# Altium schematic sheet STM32F407ZET6.SchDoc(STM32F407ZET6)
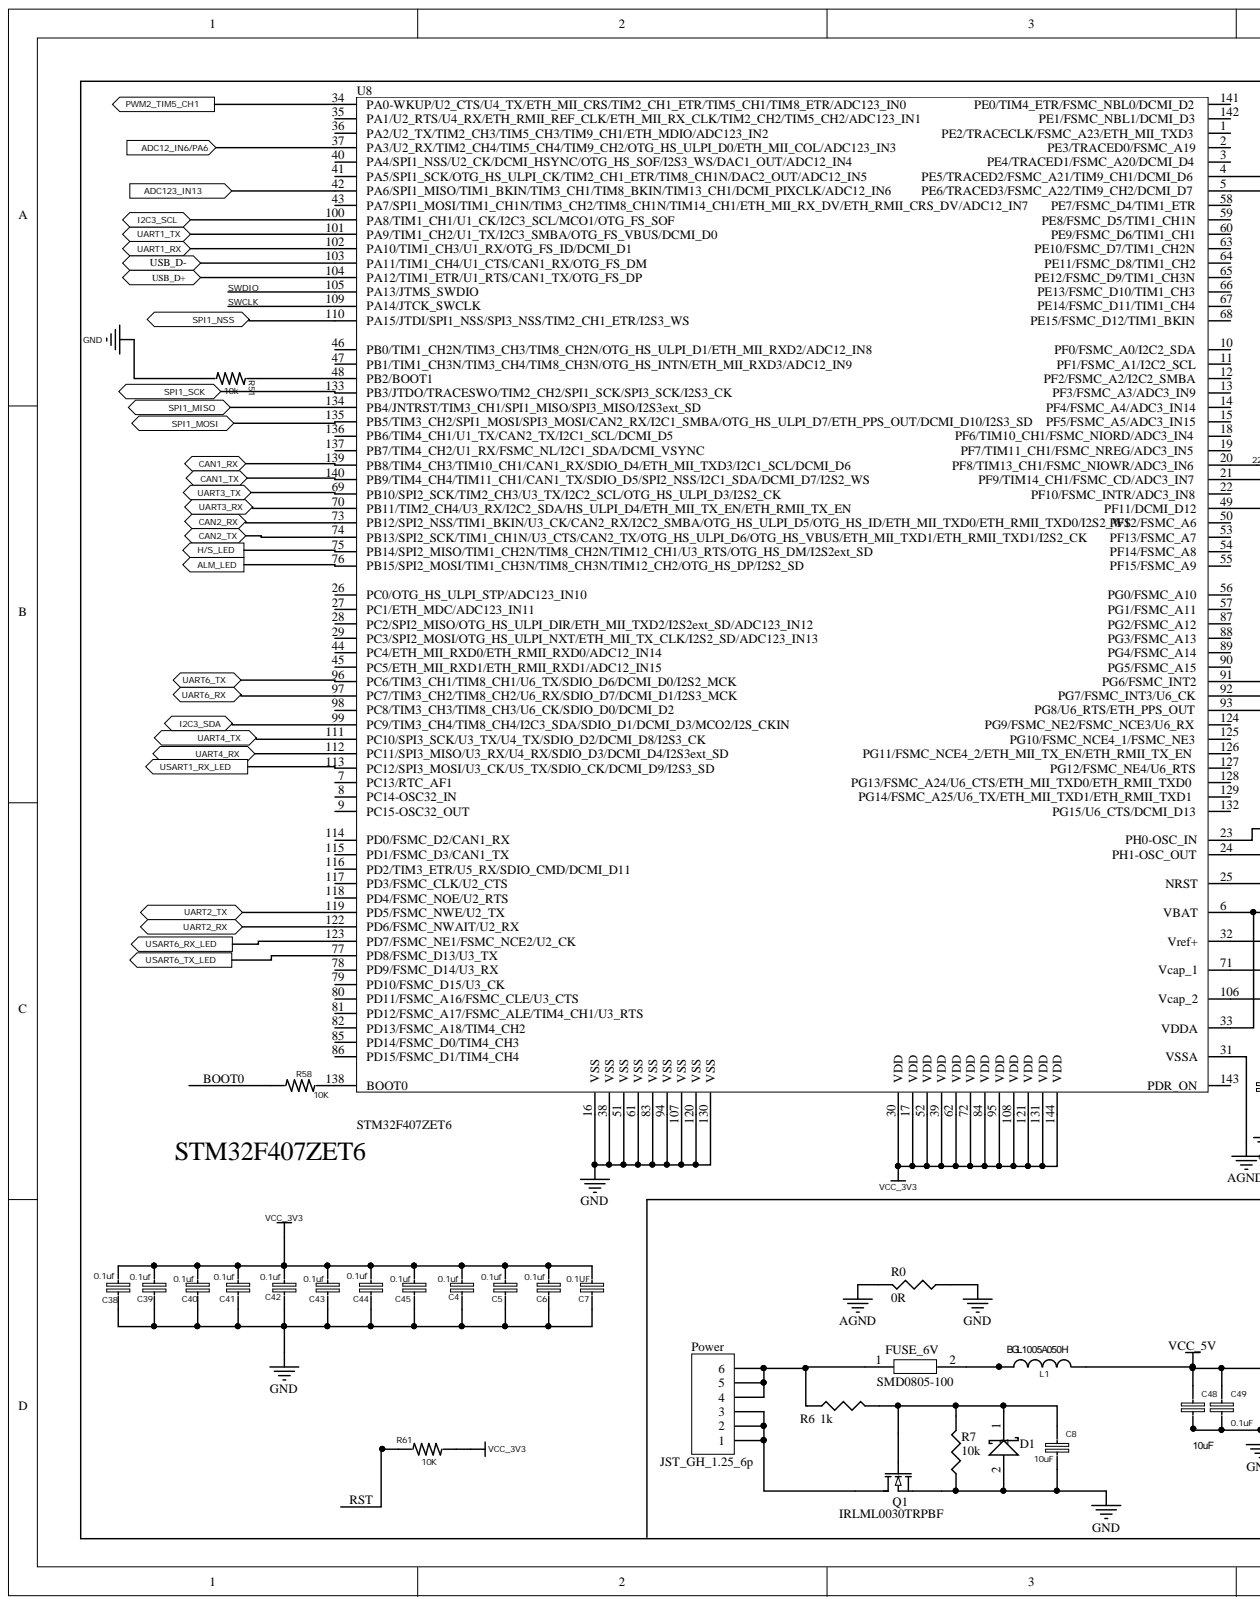
[diagram p0: STM32F407ZET6.SchDoc, left]
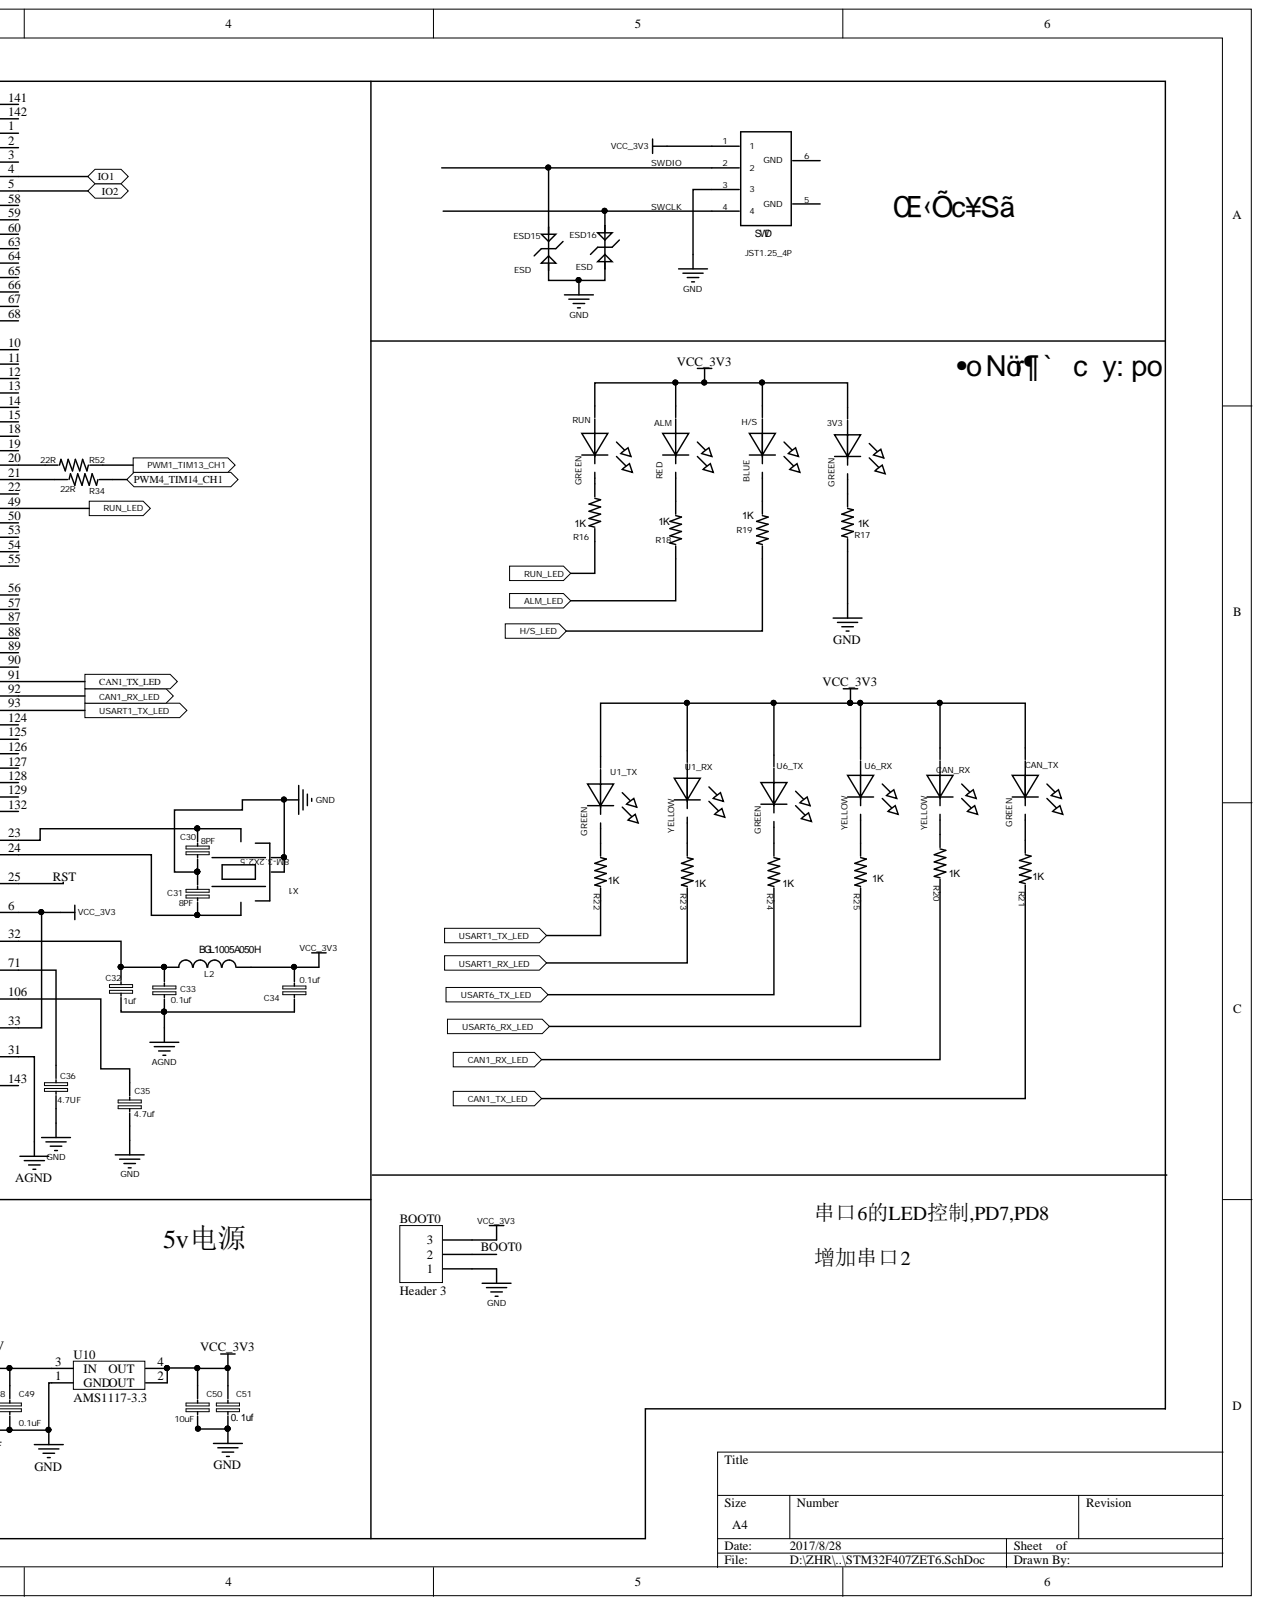
[diagram p1: STM32F407ZET6.SchDoc, right]

## Parts
3V3  GREEN  [SD0603]
ALM  RED  [SD0603]
BOOT0  Header 3  [Key3Pin]
C4  0.1uf  [SC0603]
C5  0.1uf  [SC0603]
C6  0.1uf  [SC0603]
C7  0.1UF  [SC0603]
C8  10uF  [SC0805]
C30  8PF  [SC0603]
C31  8PF  [SC0603]
C32  1uf  [SC0603]
C33  0.1uf  [SC0603]
C34  0.1uf  [SC0603]
C35  4.7uf  [SC0603]
C36  4.7UF  [SC0603]
C38  0.1uf  [SC0603]
C39  0.1uf  [SC0603]
C40  0.1uf  [SC0603]
C41  0.1uf  [SC0603]
C42  0.1uf  [SC0603]
C43  0.1uf  [SC0603]
C44  0.1uf  [SC0603]
C45  0.1uf  [SC0603]
C48  10uF  [SC0805]
C49  0.1uF  [SC0603]
C50  10uF  [SC0805]
C51  0.1uf  [SC0603]
CAN_RX  YELLOW  [SD0603]
CAN_TX  GREEN  [SD0603]
D1  (no value)  [LC-SOD-123]
ESD15  ESD  [SD-ESD0603]
ESD16  ESD  [SD-ESD0603]
FUSE_6V  SMD0805-100  [LC-0805_C]
H/S  BLUE  [SD0603]
L1  BGL1005A050H  [SL0603]
L2  BGL1005A050H  [SL0603]
Power  JST_GH_1.25_6p  [JST_6P_1.25_Standard]
Q1  IRLML0030TRPBF  [SSOT-23-0.65]
R0  0R  [LC-0603_R]
R6  1k  [LC-0603_R]
R7  10k  [LC-0603_R]
R16  1K  [SR0603]
R17  1K  [SR0603]
R18  1K  [SR0603]
R19  1K  [SR0603]
R20  1K  [SR0603]
R21  1K  [SR0603]
R22  1K  [SR0603]
R23  1K  [SR0603]
R24  1K  [SR0603]
R25  1K  [SR0603]
R34  22R  [SR0603]
R51  10k  [SR0603]
R52  22R  [SR0603]
R58  10K  [SR0603]
R61  10K  [SR0603]
RUN  GREEN  [SD0603]
SWD  JST1.25_4P  [JST_4P_1.25_Standard]
U1_RX  YELLOW  [SD0603]
U1_TX  GREEN  [SD0603]
U6_RX  YELLOW  [SD0603]
U6_TX  GREEN  [SD0603]
U8  STM32F407ZET6  [LQFP144]
U10  AMS1117-3.3  [AMS1117]
X1  8M-3.2X2.5  [XTAL-3225]

## Ports
ADC12_IN6/PA6, ADC123_IN13, ALM_LED, CAN1_RX, CAN1_RX_LED, CAN1_TX, CAN1_TX_LED, CAN2_RX, CAN2_TX, H/S_LED, I2C3_SCL, I2C3_SDA, IO1, IO2, PWM1_TIM13_CH1, PWM2_TIM5_CH1, PWM4_TIM14_CH1, RUN_LED, SPI1_MISO, SPI1_MOSI, SPI1_NSS, SPI1_SCK, UART1_RX, UART1_TX, UART2_RX, UART2_TX, UART3_RX, UART3_TX, UART4_RX, UART4_TX, UART6_RX, UART6_TX, USART1_RX_LED, USART1_TX_LED, USART6_RX_LED, USART6_TX_LED, USB_D+, USB_D-

## Nets
AGND: C32.2 C33.2 C34.1 R0.2 U8.31(VSSA)
GND: BOOT0.1 C4.1 C5.1 C6.1 C7.1 C8.2 C30.1 C31.2 C35.2 C36.2 C38.1 C39.1 C40.1 C41.1 C42.1 C43.1 C44.1 C45.1 C48.2 C49.2 C50.2 C51.2 D1.2 ESD15.1 ESD16.1 Q1.3 R0.1 R7.1 R17.1 R51.1 SWD.3 U8.16(VSS) U8.38(VSS) U8.51(VSS) U8.61(VSS) U8.83(VSS) U8.94(VSS) U8.107(VSS) U8.120(VSS) U8.130(VSS) U10.1(GND) X1.2 X1.4
VCC_3V3: 3V3.2 ALM.2 BOOT0.3 C4.2 C5.2 C6.2 C7.2 C34.2 C38.2 C39.2 C40.2 C41.2 C42.2 C43.2 C44.2 C45.2 C50.1 C51.1 CAN_RX.2 CAN_TX.2 H/S.2 L2.1 R61.2 RUN.2 SWD.1 U1_RX.2 U1_TX.2 U6_RX.2 U6_TX.2 U8.6(VBAT) U8.17(VDD) U8.30(VDD) U8.33(VDDA) U8.39(VDD) U8.52(VDD) U8.62(VDD) U8.72(VDD) U8.84(VDD) U8.95(VDD) U8.108(VDD) U8.121(VDD) U8.131(VDD) U8.144(VDD) U10.2(OUT) U10.4(OUT)
VCC_5V: C48.1 C49.1 L1.1 U10.3(IN)
ADC12_IN6/PA6: U8.37(PA3/U2_RX/TIM2_CH4/TIM5_CH4/TIM9_CH2/OTG_HS_ULPI_D0/ETH_MII_COL/ADC123_IN3) ; port ADC12_IN6/PA6
ADC123_IN13: U8.42(PA6/SPI1_MISO/TIM1_BKIN/TIM3_CH1/TIM8_BKIN/TIM13_CH1/DCMI_PIXCLK/ADC12_IN6) ; port ADC123_IN13
ALM_LED: R18.1 U8.76(PB15/SPI2_MOSI/TIM1_CH3N/TIM8_CH3N/TIM12_CH2/OTG_HS_DP/I2S2_SD) ; port ALM_LED
BOOT0: BOOT0.2 R58.1
CAN1_RX: U8.139(PB8/TIM4_CH3/TIM10_CH1/CAN1_RX/SDIO_D4/ETH_MII_TXD3/I2C1_SCL/DCMI_D6) ; port CAN1_RX
CAN1_RX_LED: R20.1 U8.92(PG7/FSMC_INT3/U6_CK) ; port CAN1_RX_LED
CAN1_TX: U8.140(PB9/TIM4_CH4/TIM11_CH1/CAN1_TX/SDIO_D5/SPI2_NSS/I2C1_SDA/DCMI_D7/I2S2_WS) ; port CAN1_TX
CAN1_TX_LED: R21.1 U8.91(PG6/FSMC_INT2) ; port CAN1_TX_LED
CAN2_RX: U8.73(PB12/SPI2_NSS/TIM1_BKIN/U3_CK/CAN2_RX/I2C2_SMBA/OTG_HS_ULPI_D5/OTG_HS_ID/ETH_MII_TXD0/ETH_RMII_TXD0/I2S2_WS) ; port CAN2_RX
CAN2_TX: U8.74(PB13/SPI2_SCK/TIM1_CH1N/U3_CTS/CAN2_TX/OTG_HS_ULPI_D6/OTG_HS_VBUS/ETH_MII_TXD1/ETH_RMII_TXD1/I2S2_CK) ; port CAN2_TX
H/S_LED: R19.1 U8.75(PB14/SPI2_MISO/TIM1_CH2N/TIM8_CH2N/TIM12_CH1/U3_RTS/OTG_HS_DM/I2S2ext_SD) ; port H/S_LED
I2C3_SCL: U8.100(PA8/TIM1_CH1/U1_CK/I2C3_SCL/MCO1/OTG_FS_SOF) ; port I2C3_SCL
I2C3_SDA: U8.99(PC9/TIM3_CH4/TIM8_CH4/I2C3_SDA/SDIO_D1/DCMI_D3/MCO2/I2S_CKIN) ; port I2C3_SDA
IO1: U8.4(PE5/TRACED2/FSMC_A21/TIM9_CH1/DCMI_D6) ; port IO1
IO2: U8.5(PE6/TRACED3/FSMC_A22/TIM9_CH2/DCMI_D7) ; port IO2
PWM1_TIM13_CH1: R52.2 ; port PWM1_TIM13_CH1
PWM2_TIM5_CH1: U8.34(PA0-WKUP/U2_CTS/U4_TX/ETH_MII_CRS/TIM2_CH1_ETR/TIM5_CH1/TIM8_ETR/ADC123_IN0) ; port PWM2_TIM5_CH1
PWM4_TIM14_CH1: R34.1 ; port PWM4_TIM14_CH1
RST: R61.1 U8.25(NRST)
RUN_LED: R16.1 U8.49(PF11/DCMI_D12) ; port RUN_LED
SPI1_MISO: U8.134(PB4/JNTRST/TIM3_CH1/SPI1_MISO/SPI3_MISO/I2S3ext_SD) ; port SPI1_MISO
SPI1_MOSI: U8.135(PB5/TIM3_CH2/SPI1_MOSI/SPI3_MOSI/CAN2_RX/I2C1_SMBA/OTG_HS_ULPI_D7/ETH_PPS_OUT/DCMI_D10/I2S3_SD) ; port SPI1_MOSI
SPI1_NSS: U8.110(PA15/JTDI/SPI1_NSS/SPI3_NSS/TIM2_CH1_ETR/I2S3_WS) ; port SPI1_NSS
SPI1_SCK: U8.133(PB3/JTDO/TRACESWO/TIM2_CH2/SPI1_SCK/SPI3_SCK/I2S3_CK) ; port SPI1_SCK
SWCLK: ESD16.2 SWD.4 U8.109(PA14/JTCK_SWCLK)
SWDIO: ESD15.2 SWD.2 U8.105(PA13/JTMS_SWDIO)
UART1_RX: U8.102(PA10/TIM1_CH3/U1_RX/OTG_FS_ID/DCMI_D1) ; port UART1_RX
UART1_TX: U8.101(PA9/TIM1_CH2/U1_TX/I2C3_SMBA/OTG_FS_VBUS/DCMI_D0) ; port UART1_TX
UART2_RX: U8.122(PD6/FSMC_NWAIT/U2_RX) ; port UART2_RX
UART2_TX: U8.119(PD5/FSMC_NWE/U2_TX) ; port UART2_TX
UART3_RX: U8.70(PB11/TIM2_CH4/U3_RX/I2C2_SDA/HS_ULPI_D4/ETH_MII_TX_EN/ETH_RMII_TX_EN) ; port UART3_RX
UART3_TX: U8.69(PB10/SPI2_SCK/TIM2_CH3/U3_TX/I2C2_SCL/OTG_HS_ULPI_D3/I2S2_CK) ; port UART3_TX
UART4_RX: U8.112(PC11/SPI3_MISO/U3_RX/U4_RX/SDIO_D3/DCMI_D4/I2S3ext_SD) ; port UART4_RX
UART4_TX: U8.111(PC10/SPI3_SCK/U3_TX/U4_TX/SDIO_D2/DCMI_D8/I2S3_CK) ; port UART4_TX
UART6_RX: U8.97(PC7/TIM3_CH2/TIM8_CH2/U6_RX/SDIO_D7/DCMI_D1/I2S3_MCK) ; port UART6_RX
UART6_TX: U8.96(PC6/TIM3_CH1/TIM8_CH1/U6_TX/SDIO_D6/DCMI_D0/I2S2_MCK) ; port UART6_TX
USART1_RX_LED: R23.1 U8.113(PC12/SPI3_MOSI/U3_CK/U5_TX/SDIO_CK/DCMI_D9/I2S3_SD) ; port USART1_RX_LED
USART1_TX_LED: R22.1 U8.93(PG8/U6_RTS/ETH_PPS_OUT) ; port USART1_TX_LED
USART6_RX_LED: R25.1 U8.123(PD7/FSMC_NE1/FSMC_NCE2/U2_CK) ; port USART6_RX_LED
USART6_TX_LED: R24.1 U8.77(PD8/FSMC_D13/U3_TX) ; port USART6_TX_LED
Net3V3_1: 3V3.1 R17.2
NetALM_1: ALM.1 R18.2
NetC8_1: C8.1 D1.1 Q1.2 R6.2 R7.2
NetC30_2: C30.2 U8.23(PH0-OSC_IN) X1.1
NetC31_1: C31.1 U8.24(PH1-OSC_OUT) X1.3
NetC32_1: C32.1 C33.1 L2.2 U8.32(Vref+)
NetC35_1: C35.1 U8.106(Vcap_2)
NetC36_1: C36.1 U8.71(Vcap_1)
NetCAN_RX_1: CAN_RX.1 R20.2
NetCAN_TX_1: CAN_TX.1 R21.2
NetFUSE_6V_1: FUSE_6V.1 Power.4 Power.5 Power.6 R6.1
NetFUSE_6V_2: FUSE_6V.2 L1.2
NetH/S_1: H/S.1 R19.2
NetPower_1: Power.1 Power.2 Power.3 Q1.1
NetR16_2: R16.2 RUN.1
NetR22_2: R22.2 U1_TX.1
NetR23_2: R23.2 U1_RX.1
NetR24_2: R24.2 U6_TX.1
NetR25_2: R25.2 U6_RX.1
NetR34_2: R34.2 U8.21(PF9/TIM14_CH1/FSMC_CD/ADC3_IN7)
NetR51_2: R51.2 U8.48(PB2/BOOT1)
NetR52_1: R52.1 U8.20(PF8/TIM13_CH1/FSMC_NIOWR/ADC3_IN6)
NetR58_2: R58.2 U8.138(BOOT0)
NetU8_103: U8.103(PA11/TIM1_CH4/U1_CTS/CAN1_RX/OTG_FS_DM)
NetU8_104: U8.104(PA12/TIM1_ETR/U1_RTS/CAN1_TX/OTG_FS_DP)

Not connected: SWD 5(GND) 6(GND); U8 1(PE2/TRACECLK/FSMC_A23/ETH_MII_TXD3) 2(PE3/TRACED0/FSMC_A19) 3(PE4/TRACED1/FSMC_A20/DCMI_D4) 7(PC13/RTC_AF1) 8(PC14-OSC32_IN) 9(PC15-OSC32_OUT) 10(PF0/FSMC_A0/I2C2_SDA) 11(PF1/FSMC_A1/I2C2_SCL) 12(PF2/FSMC_A2/I2C2_SMBA) 13(PF3/FSMC_A3/ADC3_IN9) 14(PF4/FSMC_A4/ADC3_IN14) 15(PF5/FSMC_A5/ADC3_IN15) 18(PF6/TIM10_CH1/FSMC_NIORD/ADC3_IN4) 19(PF7/TIM11_CH1/FSMC_NREG/ADC3_IN5) 22(PF10/FSMC_INTR/ADC3_IN8) 26(PC0/OTG_HS_ULPI_STP/ADC123_IN10) 27(PC1/ETH_MDC/ADC123_IN11) 28(PC2/SPI2_MISO/OTG_HS_ULPI_DIR/ETH_MII_TXD2/I2S2ext_SD/ADC123_IN12) 29(PC3/SPI2_MOSI/OTG_HS_ULPI_NXT/ETH_MII_TX_CLK/I2S2_SD/ADC123_IN13) 35(PA1/U2_RTS/U4_RX/ETH_RMII_REF_CLK/ETH_MII_RX_CLK/TIM2_CH2/TIM5_CH2/ADC123_IN1) 36(PA2/U2_TX/TIM2_CH3/TIM5_CH3/TIM9_CH1/ETH_MDIO/ADC123_IN2) 40(PA4/SPI1_NSS/U2_CK/DCMI_HSYNC/OTG_HS_SOF/I2S3_WS/DAC1_OUT/ADC12_IN4) 41(PA5/SPI1_SCK/OTG_HS_ULPI_CK/TIM2_CH1_ETR/TIM8_CH1N/DAC2_OUT/ADC12_IN5) 43(PA7/SPI1_MOSI/TIM1_CH1N/TIM3_CH2/TIM8_CH1N/TIM14_CH1/ETH_MII_RX_DV/ETH_RMII_CRS_DV/ADC12_IN7) 44(PC4/ETH_MII_RXD0/ETH_RMII_RXD0/ADC12_IN14) 45(PC5/ETH_MII_RXD1/ETH_RMII_RXD1/ADC12_IN15) 46(PB0/TIM1_CH2N/TIM3_CH3/TIM8_CH2N/OTG_HS_ULPI_D1/ETH_MII_RXD2/ADC12_IN8) 47(PB1/TIM1_CH3N/TIM3_CH4/TIM8_CH3N/OTG_HS_INTN/ETH_MII_RXD3/ADC12_IN9) 50(PF12/FSMC_A6) 53(PF13/FSMC_A7) 54(PF14/FSMC_A8) 55(PF15/FSMC_A9) 56(PG0/FSMC_A10) 57(PG1/FSMC_A11) 58(PE7/FSMC_D4/TIM1_ETR) 59(PE8/FSMC_D5/TIM1_CH1N) 60(PE9/FSMC_D6/TIM1_CH1) 63(PE10/FSMC_D7/TIM1_CH2N) 64(PE11/FSMC_D8/TIM1_CH2) 65(PE12/FSMC_D9/TIM1_CH3N) 66(PE13/FSMC_D10/TIM1_CH3) 67(PE14/FSMC_D11/TIM1_CH4) 68(PE15/FSMC_D12/TIM1_BKIN) 78(PD9/FSMC_D14/U3_RX) 79(PD10/FSMC_D15/U3_CK) 80(PD11/FSMC_A16/FSMC_CLE/U3_CTS) 81(PD12/FSMC_A17/FSMC_ALE/TIM4_CH1/U3_RTS) 82(PD13/FSMC_A18/TIM4_CH2) 85(PD14/FSMC_D0/TIM4_CH3) 86(PD15/FSMC_D1/TIM4_CH4) 87(PG2/FSMC_A12) 88(PG3/FSMC_A13) 89(PG4/FSMC_A14) 90(PG5/FSMC_A15) 98(PC8/TIM3_CH3/TIM8_CH3/U6_CK/SDIO_D0/DCMI_D2) 114(PD0/FSMC_D2/CAN1_RX) 115(PD1/FSMC_D3/CAN1_TX) 116(PD2/TIM3_ETR/U5_RX/SDIO_CMD/DCMI_D11) 117(PD3/FSMC_CLK/U2_CTS) 118(PD4/FSMC_NOE/U2_RTS) 124(PG9/FSMC_NE2/FSMC_NCE3/U6_RX) 125(PG10/FSMC_NCE4_1/FSMC_NE3) 126(PG11/FSMC_NCE4_2/ETH_MII_TX_EN/ETH_RMII_TX_EN) 127(PG12/FSMC_NE4/U6_RTS) 128(PG13/FSMC_A24/U6_CTS/ETH_MII_TXD0/ETH_RMII_TXD0) 129(PG14/FSMC_A25/U6_TX/ETH_MII_TXD1/ETH_RMII_TXD1) 132(PG15/U6_CTS/DCMI_D13) 136(PB6/TIM4_CH1/U1_TX/CAN2_TX/I2C1_SCL/DCMI_D5) 137(PB7/TIM4_CH2/U1_RX/FSMC_NL/I2C1_SDA/DCMI_VSYNC) 141(PE0/TIM4_ETR/FSMC_NBL0/DCMI_D2) 142(PE1/FSMC_NBL1/DCMI_D3) 143(PDR_ON)

## Library data of the parts
3V3 ALM CAN_RX CAN_TX H/S RUN = LED0603: PCB DECAL: SD0603; Component Kind: Standard
  3V3 CAN_TX RUN: Value: GREEN
  ALM: Value: RED
  CAN_RX: Value: YELLOW
  H/S: Value: BLUE
BOOT0 = Header 3: Header, 3-Pin; Signal Integrity: Connector; Library Name: Miscellaneous Connectors.IntLib; LatestRevisionNote: Re-released for DXP Platform.; LatestRevisionDate: 17-Jul-2002; Component Kind: Standard; Publisher: Altium Limited
C4-C8 C32-C36 C38-C45 C48-C51 = CAP0402-CAP: Component Kind: Standard
  C4-C7 C33 C34 C38-C45 C51: Value: 0.1uF; PCB DECAL: SC0603
  C8 C48 C50: Value: 10uF; PCB DECAL: SC0805; Comment_1: CAP0402-CAP
  C32: Value: 1uF; PCB DECAL: SC0603
  C35 C36: Value: 4.7uF; PCB DECAL: SC0603
  C49: Value: 0.1uF; PCB DECAL: SC0603; Comment_1: CAP0402-CAP
C30 C31 = CAP0402-CAP: Value: 8p; PCB DECAL: SC0603; Component Kind: Standard
D1 = Diode 18TQ045: Schottky Rectifier; Note: Terminal 2 is the TAB and is electrically connected to the cathode; Simulation: 18TQ045; Published: 8-Jun-2000; PackageVersion: Feb-1998; PackageReference: TO-220AC; PackageDescription: TO, Thru-Hole, Vertical, Heatsink Mounted; 3 In-Line Leads (1 Absent); Pitch 2.54 mm; Library Name: Miscellaneous Devices.IntLib; LatestRevisionNote: Re-released for DXP Platform.; LatestRevisionDate: 17-Jul-2002; DatasheetVersion: Dec-1997; ComponentLink2URL: http://www.irf.com/product-info/datasheets/data/18tq.pdf; ComponentLink2Description: Datasheet; ComponentLink1URL: http://www.irf.com/; ComponentLink1Description: Manufacturer Link; Component Kind: Standard; Publisher: Altium Limited
ESD15 ESD16 = ESD0603: Value: ESD; PCB DECAL: SD-ESD0603; Component Kind: Standard
FUSE_6V = FUSE: Component Kind: Standard; Library Name: Harry_SCH_lib.SchLib
L1 L2 = INDUCTOR: Value: BGL1005A050H; PCB DECAL: SL0603; Component Kind: Standard
Power = Header 6: Header, 6-Pin; Signal Integrity: Connector; Library Name: Miscellaneous Connectors.IntLib; LatestRevisionNote: Re-released for DXP Platform.; LatestRevisionDate: 17-Jul-2002; Component Kind: Standard; Publisher: Altium Limited
Q1 = MOSFET-N: N-Channel MOSFET; Simulation: NMOS; Published: 8-Jun-2000; PackageVersion: 1995/1996; PackageReference: E3; PackageDescription: TO, Flat Index; 3 In-Line, Axial Leads; Body Dia. 4.6mm; Lead Dia. 0.5 mm (max); Library Name: Miscellaneous Devices.IntLib; LatestRevisionNote: Re-released for DXP Platform.; LatestRevisionDate: 17-Jul-2002; Component Kind: Standard; Publisher: Altium Limited
R0 R6 R7 = RES1: Component Kind: Standard; Library Name: Harry_SCH_lib.SchLib
R16-R25 R34 R51 R58 R61 = RESISTOR0402-RES: PCB DECAL: SR0603; Component Kind: Standard
  R16-R25: Value: 1K
  R34: Value: 22R
  R51 R58 R61: Value: 10K
R52 = RESL0603: Value: 22R; PCB DECAL: SR0603; Component Kind: Standard
SWD = JST1.25_4P: PCB DECAL: 4PX1.25; Value: JST1.25_4P; Component Kind: Standard
U1_RX U1_TX U6_RX U6_TX = LED: PCB DECAL: SD0603; Component Kind: Standard
  U1_RX U6_RX: Value: YELLOW
  U1_TX U6_TX: Value: GREEN
U10 = AMS1117: Library Name: Explorer STM32F407封装库.IntLib; Component Kind: Standard
X1 = OSCILLATOR-3.2X2.5: Value: 24MHZ; PCB DECAL: XTAL-3225; Component Kind: Standard
U8 = STM32F407ZET6: Library Name: Explorer STM32F407封装库.IntLib; Description: STM32F407ZET6; Component Kind: Standard
